# Altium schematic sheet LaserMazeIO.SchDoc(LaserMazeIO)
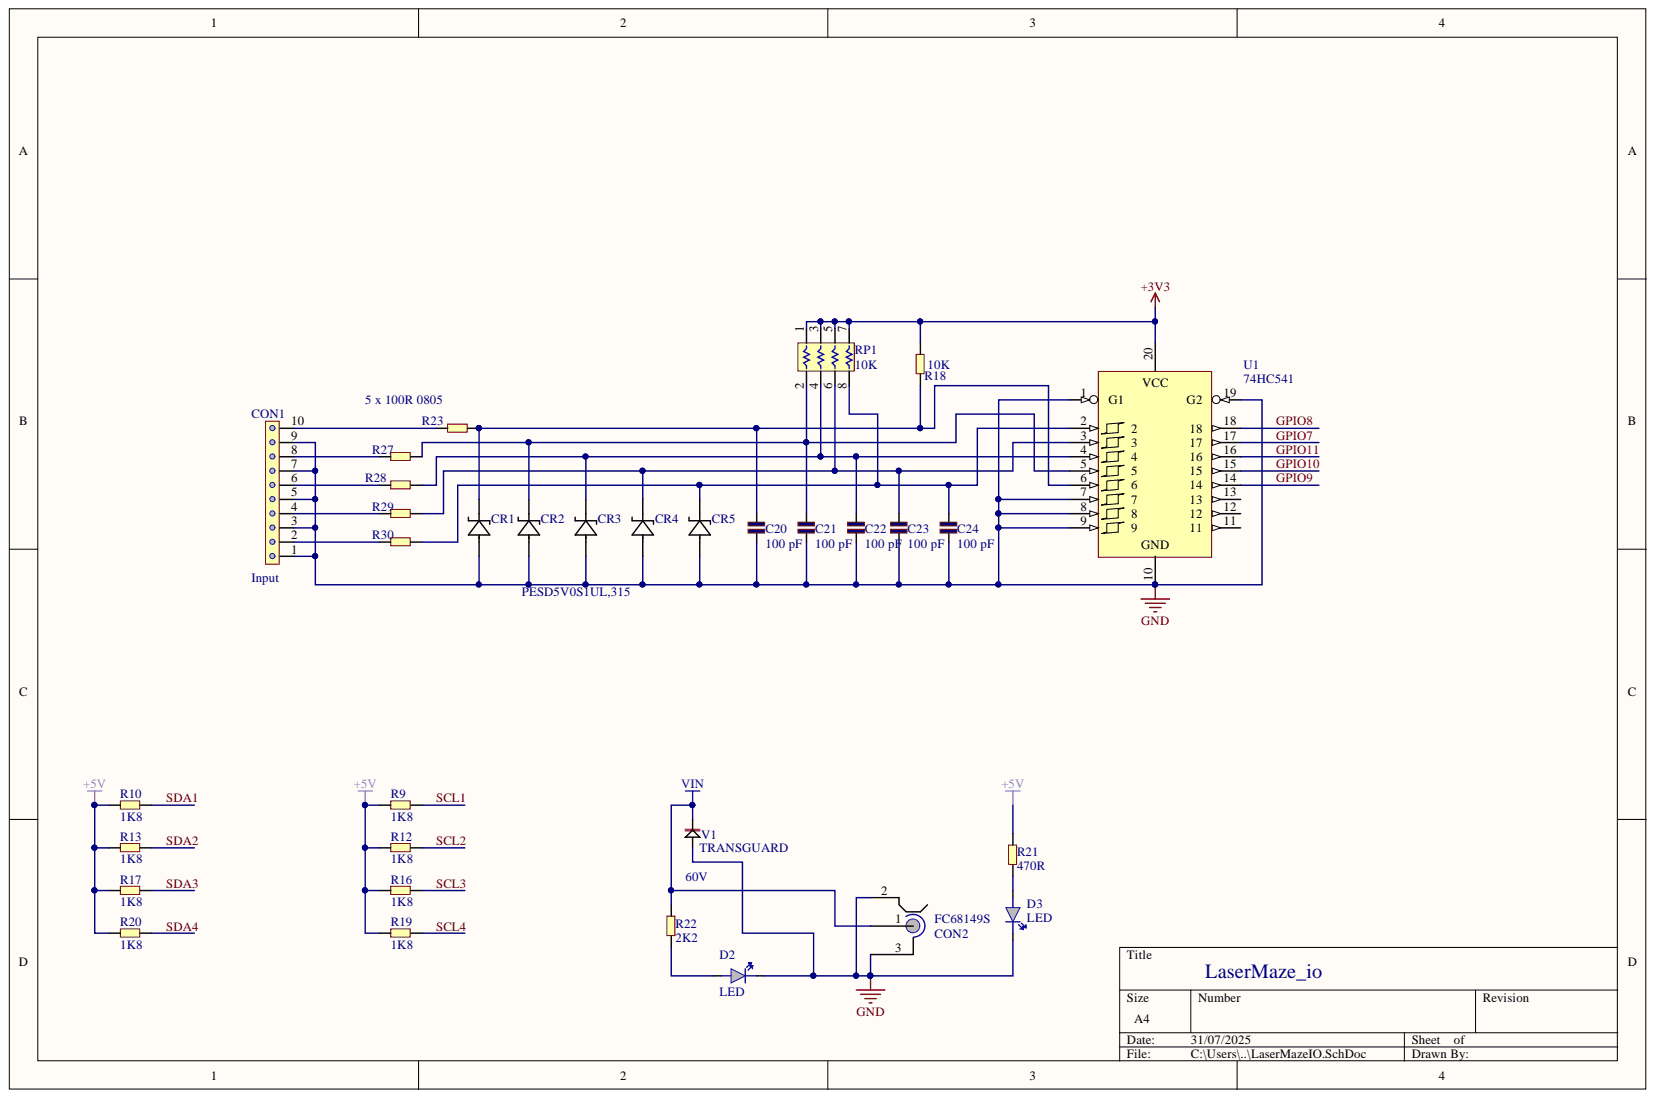
[diagram p0: LaserMazeIO.SchDoc]

## Parts
C20  100 pF  [0805]
C21  100 pF  [0805]
C22  100 pF  [0805]
C23  100 pF  [0805]
C24  100 pF  [0805]
CON1  Input  [SIP0.1_10]
CON2  FC68149S  [DC_socket]
CR1  PESD5V0S1UL,315  [SOD882]
CR2  PESD5V0S1UL,315  [SOD882]
CR3  PESD5V0S1UL,315  [SOD882]
CR4  PESD5V0S1UL,315  [SOD882]
CR5  PESD5V0S1UL,315  [SOD882]
D2  LED  [0805-POL]
D3  LED  [0805-POL]
R9  1K8  [0805]
R10  1K8  [0805]
R12  1K8  [0805]
R13  1K8  [0805]
R16  1K8  [0805]
R17  1K8  [0805]
R18  10K  [0805]
R19  1K8  [0805]
R20  1K8  [0805]
R21  470R  [0805]
R22  2K2  [0805]
R23  100R  [0805]
R27  100R  [0805]  (value not drawn)
R28  100R  [0805]  (value not drawn)
R29  100R  [0805]  (value not drawn)
R30  100R  [0805]  (value not drawn)
RP1  10K  [1206_4]
U1  74HC541  [TSSOP20B_TOS-L]
V1  TRANSGUARD  VC121026H560: 60V  [Diode8x6mm]

## Nets
+3V3: R18.1 RP1.1 RP1.3 RP1.5 RP1.7 U1.20
+5V: R9.2 R10.2 R12.2 R13.2 R16.2 R17.2 R19.2 R20.2 R21.1
GND: C20.2 C21.2 C22.2 C23.2 C24.2 CON1.1 CON1.3 CON1.5 CON1.7 CON1.9 CON2.2 CON2.3 CR1.2 CR2.2 CR3.2 CR4.2 CR5.2 D2.K D3.K U1.1(G1) U1.7 U1.8 U1.9 U1.10 U1.19(G2) V1.A
VIN: CON2.1 R22.1 V1.K
GPIO7: U1.17
GPIO8: U1.18
GPIO9: U1.14
GPIO10: U1.15
GPIO11: U1.16
SCL1: R9.1
SCL2: R12.1
SCL3: R16.1
SCL4: R19.1
SDA1: R10.1
SDA2: R13.1
SDA3: R17.1
SDA4: R20.1
NetC20_1: C20.1 CR1.1 R18.2 R23.1 U1.6
NetC21_1: C21.1 CR2.1 R27.1 RP1.2 U1.5
NetC22_1: C22.1 CR3.1 R28.1 RP1.4 U1.4
NetC23_1: C23.1 CR4.1 R29.1 RP1.6 U1.3
NetC24_1: C24.1 CR5.1 R30.1 RP1.8 U1.2
NetCON1_2: CON1.2 R30.2
NetCON1_4: CON1.4 R29.2
NetCON1_6: CON1.6 R28.2
NetCON1_8: CON1.8 R27.2
NetCON1_10: CON1.10 R23.2
NetD2_A: D2.A R22.2
NetD3_A: D3.A R21.2

Not connected: U1 11 12 13

## Library data of the parts
C20-C24 = CAP: SMT ceramic capacitor; Component Kind: Standard; Library Name: RusconX.LIB
CON1 = SIP10_0.1: Molex 10 pin connector 0.1"; Component Kind: Standard; Library Name: RusconX.LIB
CON2 = DC_socket_2.5mm: DC-10BS 2.5mm Pin; Component Kind: Standard; Library Name: RusconX.LIB
CR1-CR5 = PESD5V0S1UL,315: ESD Protection device; Component Kind: Standard; Library Name: RusconX.LIB
D2 D3 = LED: LED 0805/1206; Component Kind: Standard; Library Name: RusconX.LIB
R9 R10 R12 R13 R16-R23 R27-R30 = RES: Resistor; Text Field2: IRH; Text Field1: IRC; Component Kind: Standard; Library Name: RusconX.LIB
RP1 = RESNET-SMT4: Chip resistor array (4); Text Field3: MOQ 5000, $0.05@, 12 weeks; Text Field2: Avnet (Sydney)- Andrew; Text Field1: AVX-Kyocera Thick film chip resistor array; Component Kind: Standard; Library Name: RusconX.LIB
U1 = 74HC541 Schmitt trigger buffer: Shmitt trigger buffer; Component Kind: Standard; Library Name: RusconX.LIB
V1 = TRANSGUARD: Transient voltage suppressor; Text Field2: AVNET - Andrew Brunsdon; Text Field1: AVX - Transguard; Component Kind: Standard; Library Name: RusconX.LIB
